# Altium schematic sheet ADC.SchDoc(ADC)
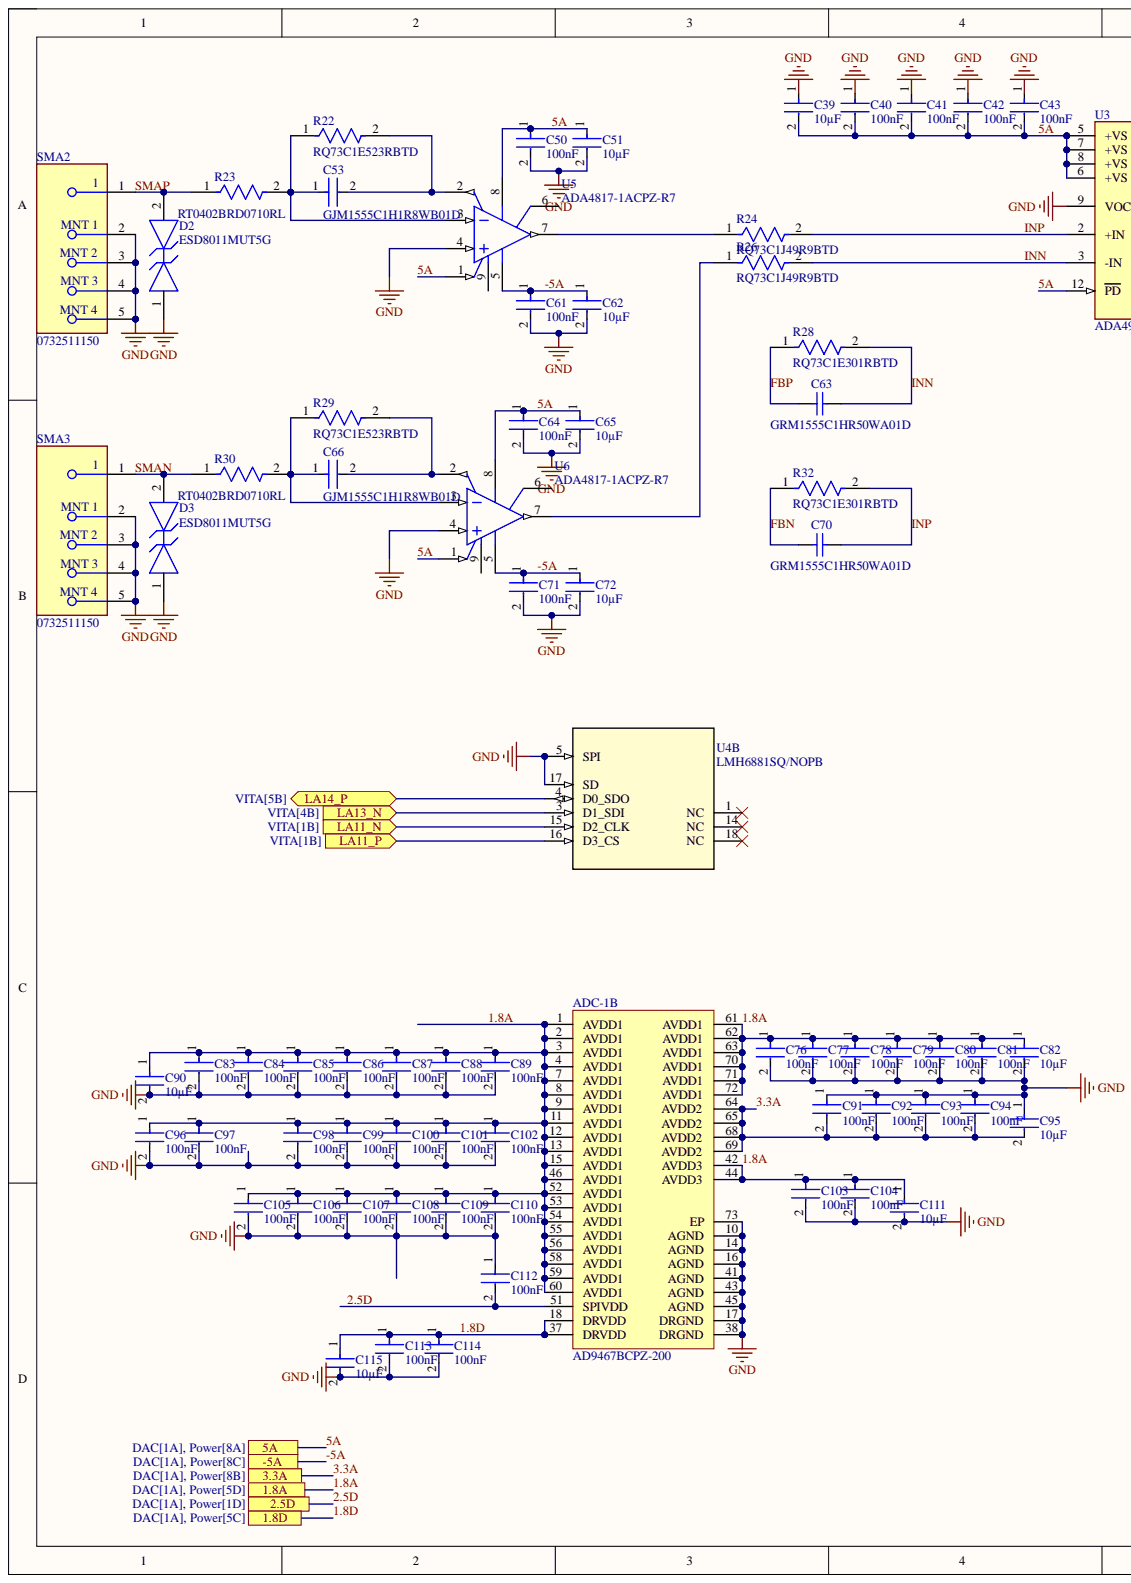
[diagram p0: ADC.SchDoc, left]
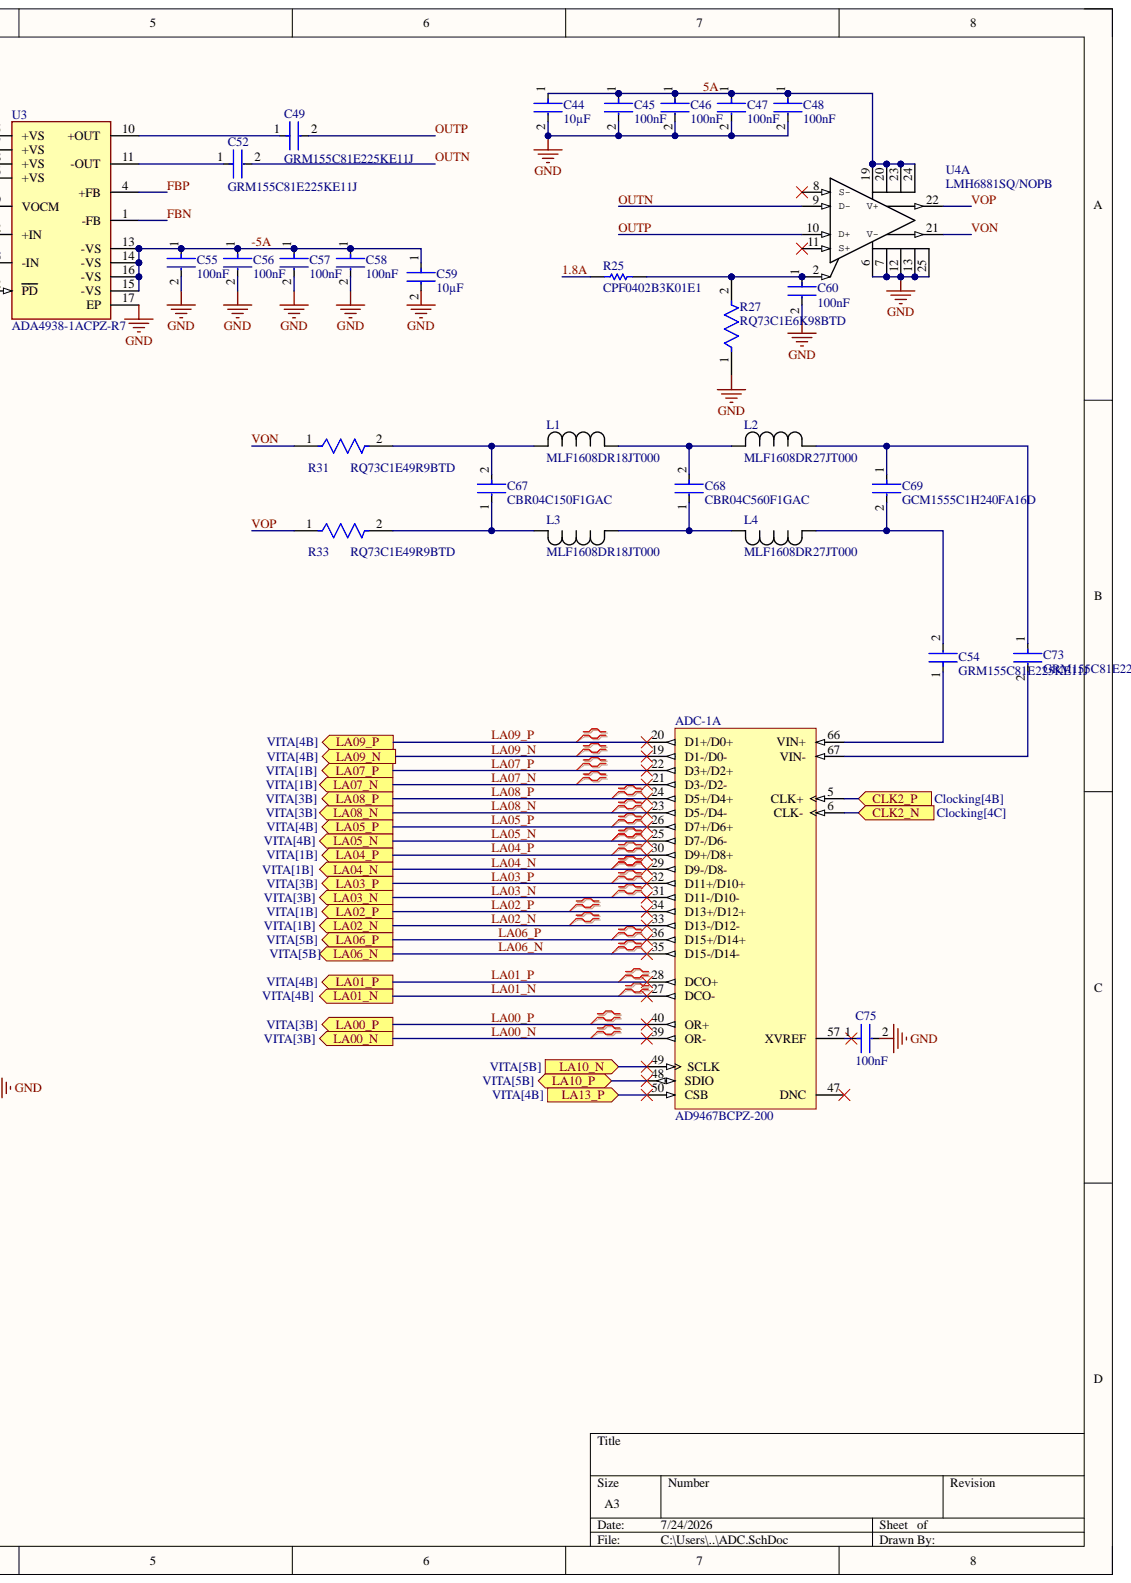
[diagram p1: ADC.SchDoc, right]

## Parts
ADC-1  AD9467BCPZ-200  [CP-72-5_N]  (parts A,B)
C39  value 10µF  [FP-GRM188-0_2-IPC_C]  (hidden comment: GRM188R61E106KA73D)
C40  value 100nF  [FP-GRM033-0_03-MFG]  (hidden comment: GRM033Z71C104KE14D)
C41  value 100nF  [FP-GRM033-0_03-MFG]  (hidden comment: GRM033Z71C104KE14D)
C42  value 100nF  [FP-GRM033-0_03-MFG]  (hidden comment: GRM033Z71C104KE14D)
C43  value 100nF  [FP-GRM033-0_03-MFG]  (hidden comment: GRM033Z71C104KE14D)
C44  value 10µF  [FP-GRM188-0_2-IPC_C]  (hidden comment: GRM188R61E106KA73D)
C45  value 100nF  [FP-GRM033-0_03-MFG]  (hidden comment: GRM033Z71C104KE14D)
C46  value 100nF  [FP-GRM033-0_03-MFG]  (hidden comment: GRM033Z71C104KE14D)
C47  value 100nF  [FP-GRM033-0_03-MFG]  (hidden comment: GRM033Z71C104KE14D)
C48  value 100nF  [FP-GRM033-0_03-MFG]  (hidden comment: GRM033Z71C104KE14D)
C49  GRM155C81E225KE11J  [FP-GRM155-0_2-IPC_C]
C50  value 100nF  [FP-GRM033-0_03-MFG]  (hidden comment: GRM033Z71C104KE14D)
C51  value 10µF  [FP-GRM188-0_2-IPC_C]  (hidden comment: GRM188R61E106KA73D)
C52  GRM155C81E225KE11J  [FP-GRM155-0_2-IPC_C]
C53  GJM1555C1H1R8WB01D  [FP-GJM155-0_05-IPC_C]
C54  GRM155C81E225KE11J  [FP-GRM155-0_2-IPC_C]
C55  value 100nF  [FP-GRM033-0_03-MFG]  (hidden comment: GRM033Z71C104KE14D)
C56  value 100nF  [FP-GRM033-0_03-MFG]  (hidden comment: GRM033Z71C104KE14D)
C57  value 100nF  [FP-GRM033-0_03-MFG]  (hidden comment: GRM033Z71C104KE14D)
C58  value 100nF  [FP-GRM033-0_03-MFG]  (hidden comment: GRM033Z71C104KE14D)
C59  value 10µF  [FP-GRM188-0_2-IPC_C]  (hidden comment: GRM188R61E106KA73D)
C60  value 100nF  [FP-GRM033-0_03-MFG]  (hidden comment: GRM033Z71C104KE14D)
C61  value 100nF  [FP-GRM033-0_03-MFG]  (hidden comment: GRM033Z71C104KE14D)
C62  value 10µF  [FP-GRM188-0_2-IPC_C]  (hidden comment: GRM188R61E106KA73D)
C63  GRM1555C1HR50WA01D  [CAPC1005X55X25LL05T10]
C64  value 100nF  [FP-GRM033-0_03-MFG]  (hidden comment: GRM033Z71C104KE14D)
C65  value 10µF  [FP-GRM188-0_2-IPC_C]  (hidden comment: GRM188R61E106KA73D)
C66  GJM1555C1H1R8WB01D  [FP-GJM155-0_05-IPC_C]
C67  CBR04C150F1GAC  [FP-CBR04C-IPC_C]
C68  CBR04C560F1GAC  [FP-CBR04C-IPC_C]
C69  GCM1555C1H240FA16D  [FP-GCM155-0_05-IPC_C]
C70  GRM1555C1HR50WA01D  [CAPC1005X55X25LL05T10]
C71  value 100nF  [FP-GRM033-0_03-MFG]  (hidden comment: GRM033Z71C104KE14D)
C72  value 10µF  [FP-GRM188-0_2-IPC_C]  (hidden comment: GRM188R61E106KA73D)
C73  GRM155C81E225KE11J  [FP-GRM155-0_2-IPC_C]
C75  value 100nF  [FP-GRM033-0_03-MFG]  (hidden comment: GRM033Z71C104KE14D)
C76  value 100nF  [FP-GRM033-0_03-MFG]  (hidden comment: GRM033Z71C104KE14D)
C77  value 100nF  [FP-GRM033-0_03-MFG]  (hidden comment: GRM033Z71C104KE14D)
C78  value 100nF  [FP-GRM033-0_03-MFG]  (hidden comment: GRM033Z71C104KE14D)
C79  value 100nF  [FP-GRM033-0_03-MFG]  (hidden comment: GRM033Z71C104KE14D)
C80  value 100nF  [FP-GRM033-0_03-MFG]  (hidden comment: GRM033Z71C104KE14D)
C81  value 100nF  [FP-GRM033-0_03-MFG]  (hidden comment: GRM033Z71C104KE14D)
C82  value 10µF  [FP-GRM188-0_2-IPC_C]  (hidden comment: GRM188R61E106KA73D)
C83  value 100nF  [FP-GRM033-0_03-MFG]  (hidden comment: GRM033Z71C104KE14D)
C84  value 100nF  [FP-GRM033-0_03-MFG]  (hidden comment: GRM033Z71C104KE14D)
C85  value 100nF  [FP-GRM033-0_03-MFG]  (hidden comment: GRM033Z71C104KE14D)
C86  value 100nF  [FP-GRM033-0_03-MFG]  (hidden comment: GRM033Z71C104KE14D)
C87  value 100nF  [FP-GRM033-0_03-MFG]  (hidden comment: GRM033Z71C104KE14D)
C88  value 100nF  [FP-GRM033-0_03-MFG]  (hidden comment: GRM033Z71C104KE14D)
C89  value 100nF  [FP-GRM033-0_03-MFG]  (hidden comment: GRM033Z71C104KE14D)
C90  value 10µF  [FP-GRM188-0_2-IPC_C]  (hidden comment: GRM188R61E106KA73D)
C91  value 100nF  [FP-GRM033-0_03-MFG]  (hidden comment: GRM033Z71C104KE14D)
C92  value 100nF  [FP-GRM033-0_03-MFG]  (hidden comment: GRM033Z71C104KE14D)
C93  value 100nF  [FP-GRM033-0_03-MFG]  (hidden comment: GRM033Z71C104KE14D)
C94  value 100nF  [FP-GRM033-0_03-MFG]  (hidden comment: GRM033Z71C104KE14D)
C95  value 10µF  [FP-GRM188-0_2-IPC_C]  (hidden comment: GRM188R61E106KA73D)
C96  value 100nF  [FP-GRM033-0_03-MFG]  (hidden comment: GRM033Z71C104KE14D)
C97  value 100nF  [FP-GRM033-0_03-MFG]  (hidden comment: GRM033Z71C104KE14D)
C98  value 100nF  [FP-GRM033-0_03-MFG]  (hidden comment: GRM033Z71C104KE14D)
C99  value 100nF  [FP-GRM033-0_03-MFG]  (hidden comment: GRM033Z71C104KE14D)
C100  value 100nF  [FP-GRM033-0_03-MFG]  (hidden comment: GRM033Z71C104KE14D)
C101  value 100nF  [FP-GRM033-0_03-MFG]  (hidden comment: GRM033Z71C104KE14D)
C102  value 100nF  [FP-GRM033-0_03-MFG]  (hidden comment: GRM033Z71C104KE14D)
C103  value 100nF  [FP-GRM033-0_03-MFG]  (hidden comment: GRM033Z71C104KE14D)
C104  value 100nF  [FP-GRM033-0_03-MFG]  (hidden comment: GRM033Z71C104KE14D)
C105  value 100nF  [FP-GRM033-0_03-MFG]  (hidden comment: GRM033Z71C104KE14D)
C106  value 100nF  [FP-GRM033-0_03-MFG]  (hidden comment: GRM033Z71C104KE14D)
C107  value 100nF  [FP-GRM033-0_03-MFG]  (hidden comment: GRM033Z71C104KE14D)
C108  value 100nF  [FP-GRM033-0_03-MFG]  (hidden comment: GRM033Z71C104KE14D)
C109  value 100nF  [FP-GRM033-0_03-MFG]  (hidden comment: GRM033Z71C104KE14D)
C110  value 100nF  [FP-GRM033-0_03-MFG]  (hidden comment: GRM033Z71C104KE14D)
C111  value 10µF  [FP-GRM188-0_2-IPC_C]  (hidden comment: GRM188R61E106KA73D)
C112  value 100nF  [FP-GRM033-0_03-MFG]  (hidden comment: GRM033Z71C104KE14D)
C113  value 100nF  [FP-GRM033-0_03-MFG]  (hidden comment: GRM033Z71C104KE14D)
C114  value 100nF  [FP-GRM033-0_03-MFG]  (hidden comment: GRM033Z71C104KE14D)
C115  value 10µF  [FP-GRM188-0_2-IPC_C]  (hidden comment: GRM188R61E106KA73D)
D2  ESD8011MUT5G  [FP-152AF-MFG]
D3  ESD8011MUT5G  [FP-152AF-MFG]
L1  MLF1608DR18JT000  [IND_1608_TDK]
L2  MLF1608DR27JT000  [IND_1608_TDK]
L3  MLF1608DR18JT000  [IND_1608_TDK]
L4  MLF1608DR27JT000  [IND_1608_TDK]
R22  RQ73C1E523RBTD  [FP-RQ731E-IPC_C]
R23  RT0402BRD0710RL  [FP-RT0402-IPC_C]
R24  RQ73C1J49R9BTD  [FP-RQ731J-IPC_B]
R25  CPF0402B3K01E1  [RESC1005X35X20NL05T10]
R26  RQ73C1J49R9BTD  [FP-RQ731J-IPC_B]
R27  RQ73C1E6K98BTD  [FP-RQ731E-IPC_C]
R28  RQ73C1E301RBTD  [FP-RQ731E-IPC_C]
R29  RQ73C1E523RBTD  [FP-RQ731E-IPC_C]
R30  RT0402BRD0710RL  [FP-RT0402-IPC_C]
R31  RQ73C1E49R9BTD  [FP-RQ731E-IPC_C]
R32  RQ73C1E301RBTD  [FP-RQ731E-IPC_C]
R33  RQ73C1E49R9BTD  [FP-RQ731E-IPC_C]
SMA2  0732511150  [FP-0732511150-MFG]
SMA3  0732511150  [FP-0732511150-MFG]
U3  ADA4938-1ACPZ-R7  [FP-CP-16-21-IPC_C]
U4  LMH6881SQ/NOPB  [RTW0024A]  (parts A,B)
U5  ADA4817-1ACPZ-R7  [ADI-CP-8-2_L]
U6  ADA4817-1ACPZ-R7  [ADI-CP-8-2_L]

## Ports
1.8A, 1.8D, 2.5D, 3.3A, 5A, -5A, CLK2_N, CLK2_P, LA00_N, LA00_P, LA01_N, LA01_P, LA02_N, LA02_P, LA03_N, LA03_P, LA04_N, LA04_P, LA05_N, LA05_P, LA06_N, LA06_P, LA07_N, LA07_P, LA08_N, LA08_P, LA09_N, LA09_P, LA10_N, LA10_P, LA11_N, LA11_P, LA13_N, LA13_P, LA14_P

## Nets
GND: ADC-1.10(AGND) ADC-1.14(AGND) ADC-1.16(AGND) ADC-1.17(DRGND) ADC-1.38(DRGND) ADC-1.41(AGND) ADC-1.43(AGND) ADC-1.45(AGND) ADC-1.73(EP) C39.1 C40.1 C41.1 C42.1 C43.1 C44.2 C45.2 C46.2 C47.2 C48.2 C50.2 C51.2 C55.2 C56.2 C57.2 C58.2 C59.2 C60.2 C61.2 C62.2 C64.2 C65.2 C71.2 C72.2 C75.2 C76.2 C77.2 C78.2 C79.2 C80.2 C81.2 C82.2 C83.2 C84.2 C85.2 C86.2 C87.2 C88.2 C89.2 C90.2 C91.1 C92.1 C93.1 C94.1 C95.1 C96.2 C97.2 C98.2 C99.2 C100.2 C101.2 C102.2 C103.2 C104.2 C105.2 C106.2 C107.2 C108.2 C109.2 C110.2 C111.2 C112.1 C113.2 C114.2 C115.2 D2.1 D3.1 R27.1 SMA2.2 SMA2.3 SMA2.4 SMA2.5 SMA3.2 SMA3.3 SMA3.4 SMA3.5 U3.9(VOCM) U3.17(EP) U4.5(SPI) U4.6(V-) U4.7 U4.12 U4.13 U4.17(SD) U4.25 U5.4 U6.4
1.8A: ADC-1.1(AVDD1) ADC-1.2(AVDD1) ADC-1.3(AVDD1) ADC-1.4(AVDD1) ADC-1.7(AVDD1) ADC-1.8(AVDD1) ADC-1.9(AVDD1) ADC-1.11(AVDD1) ADC-1.12(AVDD1) ADC-1.13(AVDD1) ADC-1.15(AVDD1) ADC-1.42(AVDD3) ADC-1.44(AVDD3) ADC-1.46(AVDD1) ADC-1.52(AVDD1) ADC-1.53(AVDD1) ADC-1.54(AVDD1) ADC-1.55(AVDD1) ADC-1.56(AVDD1) ADC-1.58(AVDD1) ADC-1.59(AVDD1) ADC-1.60(AVDD1) ADC-1.61(AVDD1) ADC-1.62(AVDD1) ADC-1.63(AVDD1) ADC-1.70(AVDD1) ADC-1.71(AVDD1) ADC-1.72(AVDD1) C76.1 C77.1 C78.1 C79.1 C80.1 C81.1 C82.1 C83.1 C84.1 C85.1 C86.1 C87.1 C88.1 C89.1 C90.1 C96.1 C97.1 C98.1 C99.1 C100.1 C101.1 C102.1 C103.1 C104.1 C105.1 C106.1 C107.1 C108.1 C109.1 C110.1 C111.1 R25.1 ; port 1.8A
1.8D: ADC-1.18(DRVDD) ADC-1.37(DRVDD) C113.1 C114.1 C115.1 ; port 1.8D
2.5D: ADC-1.51(SPIVDD) C112.2 ; port 2.5D
3.3A: ADC-1.64(AVDD2) ADC-1.65(AVDD2) ADC-1.68(AVDD2) ADC-1.69(AVDD2) C91.2 C92.2 C93.2 C94.2 C95.2 ; port 3.3A
5A: C39.2 C40.2 C41.2 C42.2 C43.2 C44.1 C45.1 C46.1 C47.1 C48.1 C50.1 C51.1 C64.1 C65.1 U3.5(+VS) U3.6(+VS) U3.7(+VS) U3.8(+VS) U3.12(PD) U4.19(V+) U4.20 U4.23 U4.24 U5.1 U5.8 U6.1 U6.8 ; port 5A
-5A: C55.1 C56.1 C57.1 C58.1 C59.1 C61.1 C62.1 C71.1 C72.1 U3.13(-VS) U3.14(-VS) U3.15(-VS) U3.16(-VS) U5.5 U6.5 ; port -5A
CLK2_N: ADC-1.6(CLK-) ; port CLK2_N
CLK2_P: ADC-1.5(CLK+) ; port CLK2_P
FBN: C70.1 R32.1 U3.1(-FB)
FBP: C63.1 R28.1 U3.4(+FB)
INN: C63.2 R26.2 R28.2 U3.3(-IN)
INP: C70.2 R24.2 R32.2 U3.2(+IN)
LA00_N: ADC-1.39(OR-) ; port LA00_N
LA00_P: ADC-1.40(OR+) ; port LA00_P
LA01_N: ADC-1.27(DCO-) ; port LA01_N
LA01_P: ADC-1.28(DCO+) ; port LA01_P
LA02_N: ADC-1.33(D13-/D12-) ; port LA02_N
LA02_P: ADC-1.34(D13+/D12+) ; port LA02_P
LA03_N: ADC-1.31(D11-/D10-) ; port LA03_N
LA03_P: ADC-1.32(D11+/D10+) ; port LA03_P
LA04_N: ADC-1.29(D9-/D8-) ; port LA04_N
LA04_P: ADC-1.30(D9+/D8+) ; port LA04_P
LA05_N: ADC-1.25(D7-/D6-) ; port LA05_N
LA05_P: ADC-1.26(D7+/D6+) ; port LA05_P
LA06_N: ADC-1.35(D15-/D14-) ; port LA06_N
LA06_P: ADC-1.36(D15+/D14+) ; port LA06_P
LA07_N: ADC-1.21(D3-/D2-) ; port LA07_N
LA07_P: ADC-1.22(D3+/D2+) ; port LA07_P
LA08_N: ADC-1.23(D5-/D4-) ; port LA08_N
LA08_P: ADC-1.24(D5+/D4+) ; port LA08_P
LA09_N: ADC-1.19(D1-/D0-) ; port LA09_N
LA09_P: ADC-1.20(D1+/D0+) ; port LA09_P
LA10_N: ADC-1.49(SCLK) ; port LA10_N
LA10_P: ADC-1.48(SDIO) ; port LA10_P
LA11_N: U4.15(D2_CLK) ; port LA11_N
LA11_P: U4.16(D3_CS) ; port LA11_P
LA13_N: U4.3(D1_SDI) ; port LA13_N
LA13_P: ADC-1.50(CSB) ; port LA13_P
LA14_P: U4.4(D0_SDO) ; port LA14_P
OUTN: C52.2 U4.9(D-)
OUTP: C49.2 U4.10(D+)
SMAN: D3.2 R30.1 SMA3.1
SMAP: D2.2 R23.1 SMA2.1
VON: R31.1 U4.21
VOP: R33.1 U4.22
NetADC-1_57: ADC-1.57(XVREF) C75.1
NetADC-1_66: ADC-1.66(VIN+) C54.1
NetADC-1_67: ADC-1.67(VIN-) C73.2
NetC49_1: C49.1 U3.10(+OUT)
NetC52_1: C52.1 U3.11(-OUT)
NetC53_1: C53.1 R22.1 R23.2 U5.3
NetC53_2: C53.2 R22.2 U5.2
NetC54_2: C54.2 C69.2 L4.2
NetC60_1: C60.1 R25.2 R27.2 U4.2
NetC66_1: C66.1 R29.1 R30.2 U6.3
NetC66_2: C66.2 R29.2 U6.2
NetC67_1: C67.1 L3.1 R33.2
NetC67_2: C67.2 L1.2 R31.2
NetC68_1: C68.1 L3.2 L4.1
NetC68_2: C68.2 L1.1 L2.2
NetC69_1: C69.1 C73.1 L2.1
NetR24_1: R24.1 U5.7
NetR26_1: R26.1 U6.7

Not connected: ADC-1 47(DNC); U4 1(NC) 8(S-) 11(S+) 14(NC) 18(NC); U5 6 9; U6 6 9

## Library data of the parts
ADC-1 = CMP-0313-02362-2: Single 16-Bit Analog-to-Digital Converter with LVDS, Parallel Interface, 200MSPS, -40 to +85 degC, CP-72-5, Pb-Free, Tray; Generic Part Number: AD9467-200; Manufacturer: Analog Devices; Channels: 1; Resolution (Bits): 16; Throughput Rate (SPS): 200M; Code_JEDEC: MO-220-VNND-4; Component Kind: Standard; ComponentLink1Description: Manufacturer URL; ComponentLink1URL: http://www.analog.com/en/index.html; ComponentLink2Description: Datasheet; ComponentLink2URL: http://www.analog.com/static/imported-files/data_sheets/AD9467.pdf; ComponentLink3Description: Package Specification; ComponentLink3URL: http://www.analog.com/static/imported-files/packages/PKG_PDF/LFCSP(CP)/CP-72/CP_72_5.pdf; ComponentLink4Description: Product URL; ComponentLink4URL: http://www.analog.com/en/analog-to-digital-converters/ad-converters/ad9467/products/product.html; DatasheetVersion: Rev.C; Interface: LVDS, Par; Library Name: Altium Content Vault; Mounting Technology: Surface Mount; PackageDescription: 72-Lead Lead Frame Chip Scale Package [LFCSP_VQ] 10 x 10 mm Body, Very Thin Quad; PackageReference: CP-72-5; PackageVersion: 041509-A; Packing: Tray; PartNumber: AD9467BCPZ-200; RoHS: Y
C39 C44 C51 C59 C62 C65 C72 C82 C90 C95 C111 C115 = CMP-001-00086-1: 25V 10uF X5R ±10% 0603 Multilayer Ceramic Capacitors MLCC - SMD/SMT ROHS; Case/Package: 0603; Component Kind: Standard; Max Operating Temperature: 85°C; Min Operating Temperature: -55°C; Mounting Technology: Surface Mount; Tolerance: 10%; Voltage Rating: 25V; Library Name: Alex Clunan
C40-C43 C45-C48 C50 C55-C58 C60 C61 C64 C71 C75-C81 C83-C89 C91-C94 C96-C110 C112-C114 = CMP-001-00085-1: 16V 100nF X7R ±10% 0201 Multilayer Ceramic Capacitors MLCC - SMD/SMT ROHS; Case/Package: 0201; Component Kind: Standard; Max Operating Temperature: 125°C; Min Operating Temperature: -55°C; Mounting Technology: Surface Mount; Tolerance: 10%; Voltage Rating: 16V; Library Name: Alex Clunan
C49 C52 C54 C73 = CMP-06035-034284-1: Chip Multilayer Ceramic Capacitors for General Purpose, 0402, 2.2uF, X6S, 22%, 10%, 25V; Component Kind: Standard; Library Name: Altium Content Vault
C53 C66 = CMP-2008-03827-2: Multi-Layer Ceramic Capacitor 1.8pF C0G  ±0.05pF 0402 Paper T/R; Voltage Rating: 50V; Capacitance: 1.8pF; Case Code (Imperial): 0402; Case Code (Metric): 1005; Case/Package: 0402; Component Kind: Standard; Depth: 0.5mm; Dielectric: C0G; Height: 0.5mm; Lead Free: Lead Free; Length: 1mm; Library Name: Altium Content Vault; Max Operating Temperature: 125°C; Min Operating Temperature: -55°C; Mount: Surface Mount; Package Quantity: 10000; Packaging: Tape and Reel; Radiation Hardening: No; Termination: SMD/SMT; Thickness: 0.022inch; Voltage Rating (DC): 50V; Width: 0.5mm
C63 C70 = CMP-1034-00022-2: Manufacturer: Murata; Component Kind: Standard; Library Name: Altium Content Vault; Part Number: GRM1555C1HR50WA01D
C67 = CMP-03020-019954-1: CAP CER 15PF 100V C0G/NP0 0402; Manufacturer: KEMET; Material: Ceramic; Temperature Characteristic: C0G; Temperature Coefficient: 30ppm/°C; Tolerance: 0.01; Automotive: No; Capacitance: 15 pF; Category: Cap; Component Kind: Standard; ComponentLink2Description: Datasheet; ComponentLink2URL: https://content.kemet.com/datasheets/KEM_C1030_CBR_SMD.pdf; Device Class L1: Passive Components; Device Class L2: Capacitors; Device Class L3: Ceramic Capacitors; Digikey Description: CAP CER 15PF 100V C0G/NP0 0402; Height: 0.55mm; Ipc Land Pattern Name: CAPC10050X50; Lead Free: Yes; Library Name: Altium Content Vault; Manufacturer Part Number: CBR04C150F1GAC; Mouser Description: Multilayer Ceramic Capacitors MLCC - SMD/SMT 100volts 15pF C0G 0402 1% Tol RF; Octopart: https://octopart.com/cbr04c150f1gac-kemet-30609229; Package: CBR04C; RoHS: TRUE; Temperature: -55°C to +125°C; Temperature Range High: +125°C; Temperature Range Low: -55°C; Value: 15 pF; Voltage Rating: 100 V
C68 = CMP-03020-023277-1: CAP CER 56PF 100V C0G/NP0 0402; Manufacturer: KEMET; Material: Ceramic; Temperature Characteristic: C0G; Temperature Coefficient: 30ppm/°C; Tolerance: 0.01; Automotive: No; Capacitance: 56 pF; Category: Cap; Component Kind: Standard; ComponentLink2Description: Datasheet; ComponentLink2URL: https://content.kemet.com/datasheets/KEM_C1030_CBR_SMD.pdf; Device Class L1: Passive Components; Device Class L2: Capacitors; Device Class L3: Ceramic Capacitors; Digikey Description: CAP CER 56PF 100V C0G/NP0 0402; Height: 0.55mm; Ipc Land Pattern Name: CAPC10050X50; Lead Free: Yes; Library Name: Altium Content Vault; Manufacturer Part Number: CBR04C560F1GAC; Mouser Description: Multilayer Ceramic Capacitors MLCC - SMD/SMT 100volts 56pF C0G 0402 1% Tol RF; Octopart: https://octopart.com/cbr04c560f1gac-kemet-30609234; Package: CBR04C; RoHS: TRUE; Temperature: -55°C to +125°C; Temperature Range High: +125°C; Temperature Range Low: -55°C; Value: 56 pF; Voltage Rating: 100 V
C69 = CMP-06035-030316-1: Ceramic Capacitor for Automotive 24pF ±1% 50VDC C0G 0402 Paper T/R; Component Kind: Standard; Library Name: Altium Content Vault
D2 D3 = CMP-00278-53637930-1: ESD8011MUT5G ESD8011MUT5G, Bi-Directional ESD Protection Diode, 2-Pin X3DFN; Manufacturer Lifecycle Status: ACTIVE; Breakdown Voltage: 6.5V; Capacitance: 100fF; Clamping Voltage: 17.2V; Direction: Bidirectional; Element Configuration: Common Cathode; ESD Protection: Yes; Max Junction Temperature (Tj): 125°C; Max Reverse Leakage Current: 1µA; Min Breakdown Voltage: 7.3V; Number of Bidirectional Channels: 1; Operating Supply Voltage: 5.5V; Peak Pulse Current: 3.6A; Peak Pulse Power: 34W; Power Line Protection: No; Series Resistance: 1Ω; Test Current: 1mA; Case/Package: DFN; Component Kind: Standard; Composition: Zener; Contact Plating: Gold; Height: 280µm; Lead Free: Lead Free; Length: 660µm; Library Name: Altium Content Vault; Lifecycle Status: Production; Max Operating Temperature: 125°C; Min Operating Temperature: -55°C; Mount: Surface Mount; NexarPartId: 53637930; Number of Channels: 1; Number of Pins: 2; Packaging: Cut Tape; REACH SVHC: No SVHC; Reverse Standoff Voltage: 5.5V; RoHS: Compliant; Schedule B: 8536300000; Width: 360µm
L1 L3 = MLF1608DR18JT000: No Description Available; Manufacturer_Name: TDK; Component Kind: Standard; Digi-Key_Part_Number_1: MLF1608DR18JT000-ND; Library Name: MLF1608DR18JT000.IntLib; Manufacturer_Part_Number: MLF1608DR18JT000; Copyright: Copyright (C) 2026 Ultra Librarian. All rights reserved.
L2 L4 = MLF1608DR27JT000: No Description Available; Manufacturer_Name: TDK; Component Kind: Standard; Digi-Key_Part_Number_1: 445-181325-1-ND; Digi-Key_Part_Number_2: 445-181325-2-ND; Digi-Key_Part_Number_3: MLF1608DR27JT000-ND; Digi-Key_Part_Number_4: 445-MLF1608DR27JT000CT-ND; Digi-Key_Part_Number_5: 445-MLF1608DR27JT000DKR-ND; Digi-Key_Part_Number_6: 445-MLF1608DR27JT000TR-ND; Library Name: MLF1608DR27JT000.IntLib; Manufacturer_Part_Number: MLF1608DR27JT000; Copyright: Copyright (C) 2026 Ultra Librarian. All rights reserved.
R22 R29 = CMP-03211-011285-1: RQ73 0402 523Ω 0.0625W 10ppm/°C 0.1% 50V; Component Kind: Standard; Library Name: Altium Content Vault
R23 R30 = CMP-2002-02916-2: RES 10.0 OHM 1/16W .1% SMD 0402; Manufacturer: Yageo; Power Rating: 0.063W; Resistance: 10Ω; Temperature Coefficient: 25 ppm/°C; Tolerance: 0.001; Value: 10Ω; Voltage Rating: 50V; Automotive: No; Category: Res; Component Kind: Standard; ComponentLink2Description: Datasheet; ComponentLink2URL: http://www.yageo.com/documents/recent/PYu-RT_1-to-0.01_RoHS_L_9.pdf; Composition: Thin Film; Device Class L1: Passive Components; Device Class L2: Resistors; Device Class L3: Chip SMD Resistors; Digikey Description: RES 10.0 OHM 1/16W .1% SMD 0402; Footprint Url: http://www.yageo.com/exep/pages/download/literatures/PYu-R_Mount_10.pdf; Height: 0.35mm; Ipc Land Pattern Name: RESC10050X30; Lead Free: Yes; Library Name: Altium Content Vault; Manufacturer Part Number: RT0402BRD0710RL; Mouser Description: RT Series 0402 0.063 W 10 Ohm ±0.1 % ±25 ppm/°C SMT Thin Film Chip Resistor; Octopart: https://octopart.com/rt0402brd0710rl-yageo-1423708; Package: RT0402; RoHS: TRUE; Series: RT; Temperature: -55°C to +155°C; Temperature Range High: +155°C; Temperature Range Low: -55°C
R24 R26 = CMP-03211-011343-1: RQ73 0603 49.9Ω  0.15W 10ppm/°C 0.1% 75V; Component Kind: Standard; Library Name: Altium Content Vault
R25 = CMP-2002-03280-1: Manufacturer: TE Connectivity / AMP; Component Kind: Standard; Library Name: Altium Content Vault; Part Number: CPF0402B3K01E1
R27 = CMP-03211-009197-1: RQ73 0402 6.98KΩ 0.0625W 10ppm/°C 0.1% 50V; Manufacturer: TE; Automotive Grade: Grade 0; Automotive: Yes; Category: Res; Component Kind: Standard; ComponentLink2Description: Datasheet; ComponentLink2URL: https://www.te.com/commerce/DocumentDelivery/DDEController?Action=showdoc&DocId=Data+Sheet%7F1773270-2%7FA%7Fpdf%7FEnglish%7FENG_DS_1773270-2_A.pdf%7F4-2176362-442; Composition: Thin Film; Device Class L1: Passive Components; Device Class L2: Resistors; Device Class L3: Chip SMD Resistors; Height: 0.4mm; Ipc Land Pattern Name: RESC10050X30; Lead Free: Yes; Library Name: Altium Content Vault; Manufacturer Part Number: RQ73C1E6K98BTD; Octopart: https://octopart.com/rq73c1e6k98btd-te+connectivity-93908040; Package: RQ731E; Power Rating: 0.0625W; Resistance: 6.98KΩ; RoHS: TRUE; Series: RQ73; Temperature: -55°C to +155°C; Temperature Coefficient: 10ppm/°C; Temperature Range High: +155°C; Temperature Range Low: -55°C; Tolerance: 0.1%; Value: 6.98KΩ; Voltage Rating: 50V
R28 R32 = CMP-03211-006645-1: RQ73 0402 301Ω 0.0625W 10ppm/°C 0.1% 50V; Manufacturer: TE; Automotive Grade: Grade 0; Automotive: Yes; Category: Res; Component Kind: Standard; ComponentLink2Description: Datasheet; ComponentLink2URL: https://www.te.com/commerce/DocumentDelivery/DDEController?Action=showdoc&DocId=Data+Sheet%7F1773270-2%7FA%7Fpdf%7FEnglish%7FENG_DS_1773270-2_A.pdf%7F4-2176362-284; Composition: Thin Film; Device Class L1: Passive Components; Device Class L2: Resistors; Device Class L3: Chip SMD Resistors; Height: 0.4mm; Ipc Land Pattern Name: RESC10050X30; Lead Free: Yes; Library Name: Altium Content Vault; Manufacturer Part Number: RQ73C1E301RBTD; Octopart: https://octopart.com/8-2176362-5-te+connectivity-93905739; Package: RQ731E; Power Rating: 0.0625W; Resistance: 301Ω; RoHS: TRUE; Series: RQ73; Temperature: -55°C to +155°C; Temperature Coefficient: 10ppm/°C; Temperature Range High: +155°C; Temperature Range Low: -55°C; Tolerance: 0.1%; Value: 301Ω; Voltage Rating: 50V
R31 R33 = CMP-03211-011281-1: RQ73 0402 49.9Ω 0.0625W 10ppm/°C 0.1% 50V; Component Kind: Standard; Library Name: Altium Content Vault
SMA2 SMA3 = CMP-04764-000001-1: CONN SMA RCPT STR 50OHM EDGE MNT; Manufacturer: Molex Inc; Conn Orientation: Horizontal; Dielectric Material: PTFE; Frequency: 18GHz; Impedance: 50Ω; Is Female: yes; Number Of Contacts: 1; Shielding: true; Voltage Rating: 500V; Automotive: No; Category: Conn; Component Kind: Standard; ComponentLink2Description: Datasheet; ComponentLink2URL: https://www.molex.com/webdocs/datasheets/pdf/en-us/0732511150_RF_COAX_CONNECTORS.pdf; Contact Material: Gold,Nickel; Device Class L1: Connectors; Device Class L2: RF / Coaxial Connectors; Device Class L3: unset; Digikey Description: CONN SMA RCPT STR 50OHM EDGE MNT; Footprint Url: https://www.molex.com/pdm_docs/sd/732511150_sd.pdf; Lead Free: Yes; Library Name: Altium Content Vault; Manufacturer Part Number: 0732511150; Mouser Description: RF Connectors / Coaxial Connectors SMA ST PCB-JCK STD EMT; Octopart: https://octopart.com/73251-1150-molex-777272; Package: 0; RoHS: TRUE; Temperature: -65°C to +165°C; Temperature Range High: +165°C; Temperature Range Low: -65°C
U3 = CMP-09114-000002-1: IC ADC DRIVER 16LFCSP; Manufacturer: Analog Devices; Bandwidth: 1000MHz; Input Offset Voltage: 3mV; Max Junction Temp: +150°C; Max Supply Voltage: 11V; Min Supply Voltage: 4.5V; Nominal Supply Current: 37mA; Number Of Channels: 1; Standoff Height: 0.02mm; Automotive: No; Category: IC; Component Kind: Standard; ComponentLink2Description: Datasheet; ComponentLink2URL: https://www.analog.com/media/en/technical-documentation/data-sheets/ADA4938-1_4938-2.pdf; Device Class L1: Integrated Circuits (ICs); Device Class L2: Linear ICs; Device Class L3: unset; Digikey Description: IC ADC DRIVER 16LFCSP; Height: 0.8mm; Ipc Land Pattern Name: QFN50P300X300X75-16; Lead Free: Yes; Library Name: Altium Content Vault; Manufacturer Part Number: ADA4938-1ACPZ-R7; Mouser Description: SP Amp DIFF AMP Single ±5.5V/11V 16-Pin LFCSP EP T/R; Octopart: https://octopart.com/ada4938-1acpz-r7-analog+devices-46641555; Package: CP-16-21; RoHS: TRUE; Temperature: -40°C to +85°C; Temperature Range High: +85°C; Temperature Range Low: -40°C
U4 = LMH6881SQNOPB: 2.4GHz Programmable Differential Amplifier with Gain Control 24-WQFN -40 to 85; Manufacturer: Texas Instruments; PartNumber: LMH6881SQX/NOPB; CompType: IC; Alternate_PartNumber: LMH6881SQ/NOPB; Component Kind: Standard; Library Name: LMH6881SQNOPB.IntLib; Manufacturer_Name: Texas Instruments; Manufacturer_Part_Number: LMH6881SQ/NOPB; MountType: SMT; PackageReference: RTW0024A; RefDes: RefDes; RoHS: Y; TYPE: TYPE; Copyright: Copyright (C) 2025 Ultra Librarian. All rights reserved.
U5 U6 = CMP-0384-00096-1: Single Channel Low Noise Operational Amplifier, 1.05 GHz BW, 870 V/us SR, Industrial, 8-pin QFN (CP-8-2), Reel; Manufacturer: Analog Devices; Amplifiers Per Package: 1; Input Bias Current: 2pA; Input Offset Voltage: 2mV; Iq/Amp: 21mA; V Span Max: 10V; V Span Min: 5V; Component Kind: Standard; ComponentLink1Description: Manufacturer URL; ComponentLink1URL: http://www.analog.com/en/index.html; ComponentLink2Description: Datasheet; ComponentLink2URL: http://www.analog.com/static/imported-files/data_sheets/ADA4817-1_4817-2.pdf; ComponentLink3Description: Package Specification; ComponentLink3URL: http://www.analog.com/static/imported-files/packages/56953322593982CP_8_2.pdf; DatasheetVersion: Rev. A; Generic Part Number: ADA4817-1; Library Name: Altium Content Vault; Mounting Technology: Surface Mount; PackageDescription: 8-Pin Lead Frame Chip Scale Package, Body 3 x 3 mm, Pitch 0.5 mm; PackageReference: CP-8-2; PackageVersion: 061507-B; PartNumber: ADA4817-1ACPZ-R7; Slew Rate: 870V/us; Small Signal Bandwidth: 1.05GHz
